# Altium schematic sheet SystemCTL.SchDoc
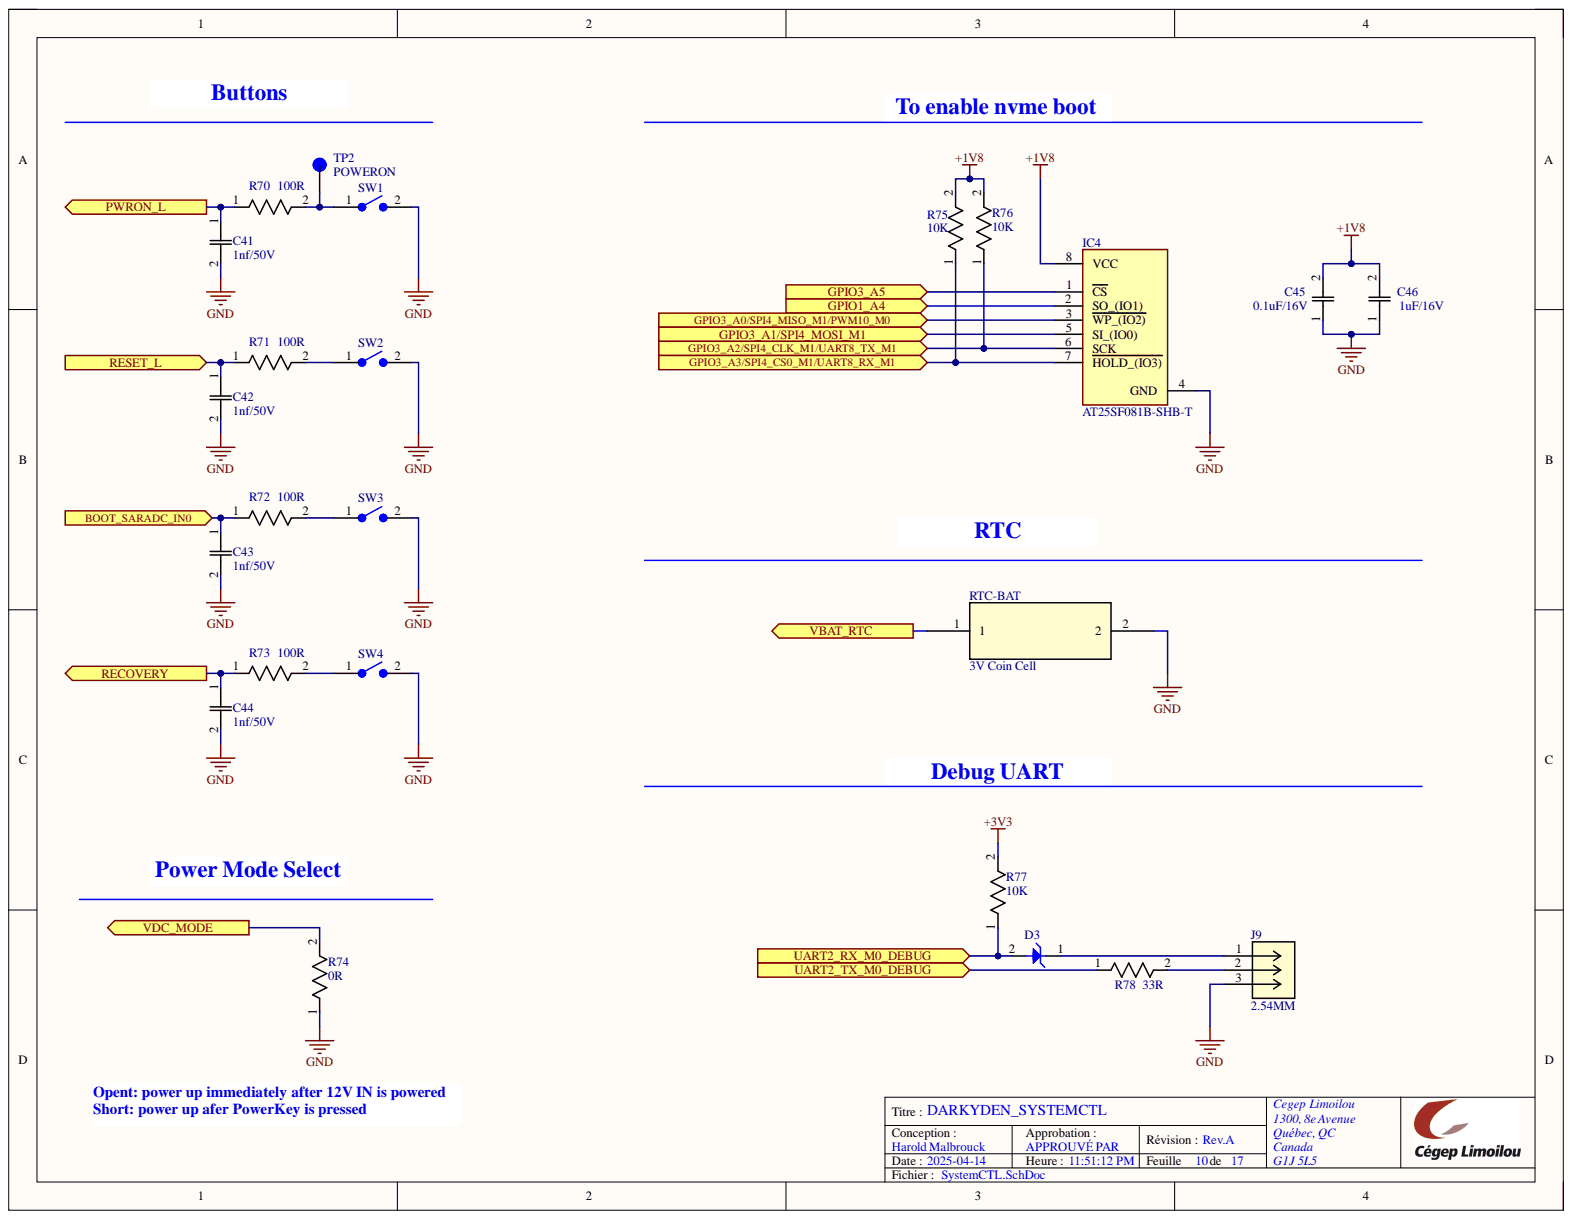
[diagram p0: SystemCTL.SchDoc]

## Parts
C41  1nf/50V  [CAP_CC0805_YAG]
C42  1nf/50V  [CAP_CC0805_YAG]
C43  1nf/50V  [CAP_CC0805_YAG]
C44  1nf/50V  [CAP_CC0805_YAG]
C45  0.1uF/16V  [CAP_CC0805_YAG]
C46  1uF/16V  [CAP_CC0805_YAG]
D3  Schottky  [SODFL1608X70N]  (value not drawn)
IC4  AT25SF081B-SHB-T  [SOIC127P798X216-8N]
J9  2.54MM  [CONN_M20-99903XX_HRW]
R70  100R  [RES_0816_SUS]
R71  100R  [RES_0816_SUS]
R72  100R  [RES_0816_SUS]
R73  100R  [RES_0816_SUS]
R74  0R  [RES_0816_SUS]
R75  10K  [RES_0816_SUS]
R76  10K  [RES_0816_SUS]
R77  10K  [RES_0816_SUS]
R78  33R  [RES_0816_SUS]
RTC-BAT  3V Coin Cell  [BH-49A-5-T-BK_ADM]
SW1  SW_SMT  [SW_TS06-667-25-BK-100-G-SMT-TR]  (value not drawn)
SW2  SW_SMT  [SW_TS06-667-25-BK-100-G-SMT-TR]  (value not drawn)
SW3  SW_SMT  [SW_TS06-667-25-BK-100-G-SMT-TR]  (value not drawn)
SW4  SW_SMT  [SW_TS06-667-25-BK-100-G-SMT-TR]  (value not drawn)
TP2  POWERON  [KEYSTONE_5006]

## Ports
BOOT_SARADC_IN0, GPIO1_A4, GPIO3_A0/SPI4_MISO_M1/PWM10_M0, GPIO3_A1/SPI4_MOSI_M1, GPIO3_A2/SPI4_CLK_M1/UART8_TX_M1, GPIO3_A3/SPI4_CS0_M1/UART8_RX_M1, GPIO3_A5, PWRON_L, RECOVERY, RESET_L, UART2_RX_M0_DEBUG, UART2_TX_M0_DEBUG, VBAT_RTC, VDC_MODE

## Nets
+1V8: C45.2 C46.2 IC4.8(VCC) R75.2 R76.2
+3V3: R77.2
GND: C41.2 C42.2 C43.2 C44.2 C45.1 C46.1 IC4.4(GND) J9.3 R74.1 RTC-BAT.2 SW1.2 SW2.2 SW3.2 SW4.2
BOOT_SARADC_IN0: C43.1 R72.1 ; port BOOT_SARADC_IN0
GPIO1_A4: IC4.2(SO_(IO1)) ; port GPIO1_A4
GPIO3_A0/SPI4_MISO_M1/PWM10_M0: IC4.3(WP_(IO2)) ; port GPIO3_A0/SPI4_MISO_M1/PWM10_M0
GPIO3_A1/SPI4_MOSI_M1: IC4.5(SI_(IO0)) ; port GPIO3_A1/SPI4_MOSI_M1
GPIO3_A2/SPI4_CLK_M1/UART8_TX_M1: IC4.6(SCK) R76.1 ; port GPIO3_A2/SPI4_CLK_M1/UART8_TX_M1
GPIO3_A3/SPI4_CS0_M1/UART8_RX_M1: IC4.7(HOLD_(IO3)) R75.1 ; port GPIO3_A3/SPI4_CS0_M1/UART8_RX_M1
GPIO3_A5: IC4.1(CS) ; port GPIO3_A5
PWRON_L: C41.1 R70.1 ; port PWRON_L
RECOVERY: C44.1 R73.1 ; port RECOVERY
RESET_L: C42.1 R71.1 ; port RESET_L
UART2_RX_M0_DEBUG: D3.2 R77.1 ; port UART2_RX_M0_DEBUG
UART2_TX_M0_DEBUG: R78.1 ; port UART2_TX_M0_DEBUG
VBAT_RTC: RTC-BAT.1 ; port VBAT_RTC
VDC_MODE: R74.2 ; port VDC_MODE
NetD3_1: D3.1 J9.1
NetJ9_2: J9.2 R78.2
NetR70_2: R70.2 SW1.1 TP2.TP
NetR71_2: R71.2 SW2.1
NetR72_2: R72.2 SW3.1
NetR73_2: R73.2 SW4.1

## Library data of the parts
C41-C46 = Capacitor_0805: No Description Available; Mfr_Name: Yageo; Component Kind: Standard; Library Name: Capacitor_0805.SchLib
D3 = Schottky: Diode -SOD523; Manufacturer_Name: onsemi; Manufacturer_Part_Number: RB520S30T1G; Arrow Part Number: RB520S30T1G; Arrow Price/Stock: https://www.arrow.com/en/products/rb520s30t1g/on-semiconductor?region=nac; Component Kind: Standard; Datasheet Link: https://www.onsemi.com/pub/Collateral/RB520S30T1-D.PDF; Height: 0.7mm; Library Name: SamacSys.SchLib; Mouser Part Number: 863-RB520S30T1G; Mouser Price/Stock: https://www.mouser.co.uk/ProductDetail/onsemi/RB520S30T1G?qs=xZq1yRCsb1drMBOPt79ooQ%3D%3D
IC4 = AT25SF081B-SHB-T: Integrated Circuit; Manufacturer_Name: Adesto Technologies; Component Kind: Standard; Datasheet Link: https://eu.mouser.com/datasheet/2/590/at25sf081b-1888118.pdf; Height: 2.16mm; Library Name: SamacSys.SchLib; Manufacturer_Part_Number: AT25SF081B-SHB-T; Mouser Part Number: 988-AT25SF081B-SHB-T; Mouser Price/Stock: https://www.mouser.co.uk/ProductDetail/Adesto-Technologies/AT25SF081B-SHB-T?qs=wnTfsH77Xs46OZOQzObQdg%3D%3D
J9 = M20-9990345: No Description Available; Mfr_Name: Harwin; Component Kind: Standard; Library Name: M20-9990345.IntLib; RefDes: RefDes; Type: Type
R70-R78 = RG1608P-104-B-T5: No Description Available; Mfr_Name: Susumu; Component Kind: Standard; Library Name: RES_SMD_0603.SchLib
RTC-BAT = BH-49A-5-T-BK: No Description Available; Mfr_Name: ADAM TECHNOLOGIES INC; Component Kind: Standard; Library Name: BH-49A-5-T-BK.IntLib; RefDes: RefDes; Type: Type
SW1-SW4 = SW_SMT: MF: {'manufacturer': u'CUI'}; MP: TS06-667-25-BK-100-G-SMT-TR; Availability: Unavailable; Component Kind: Standard; Library Name: Switch_SMD.SchLib; Package: None; Price: None
TP2 = 5006: Test Point Compact THM PC .350 L .125 OD Heat Resistant Nylon 46 Black | Keystone Electronics 5006; MF: Keystone Electronics Corp.; Check_prices: https://www.snapeda.com/parts/5006/Keystone/view-part/?ref=eda; Component Kind: Standard; Library Name: test_point.SchLib; MP: 5006; Package: NON STANDARD-1 Keystone; SnapEDA_Link: https://www.snapeda.com/parts/5006/Keystone/view-part/?ref=snap
